# Altium schematic sheet Schematic1.SchDoc
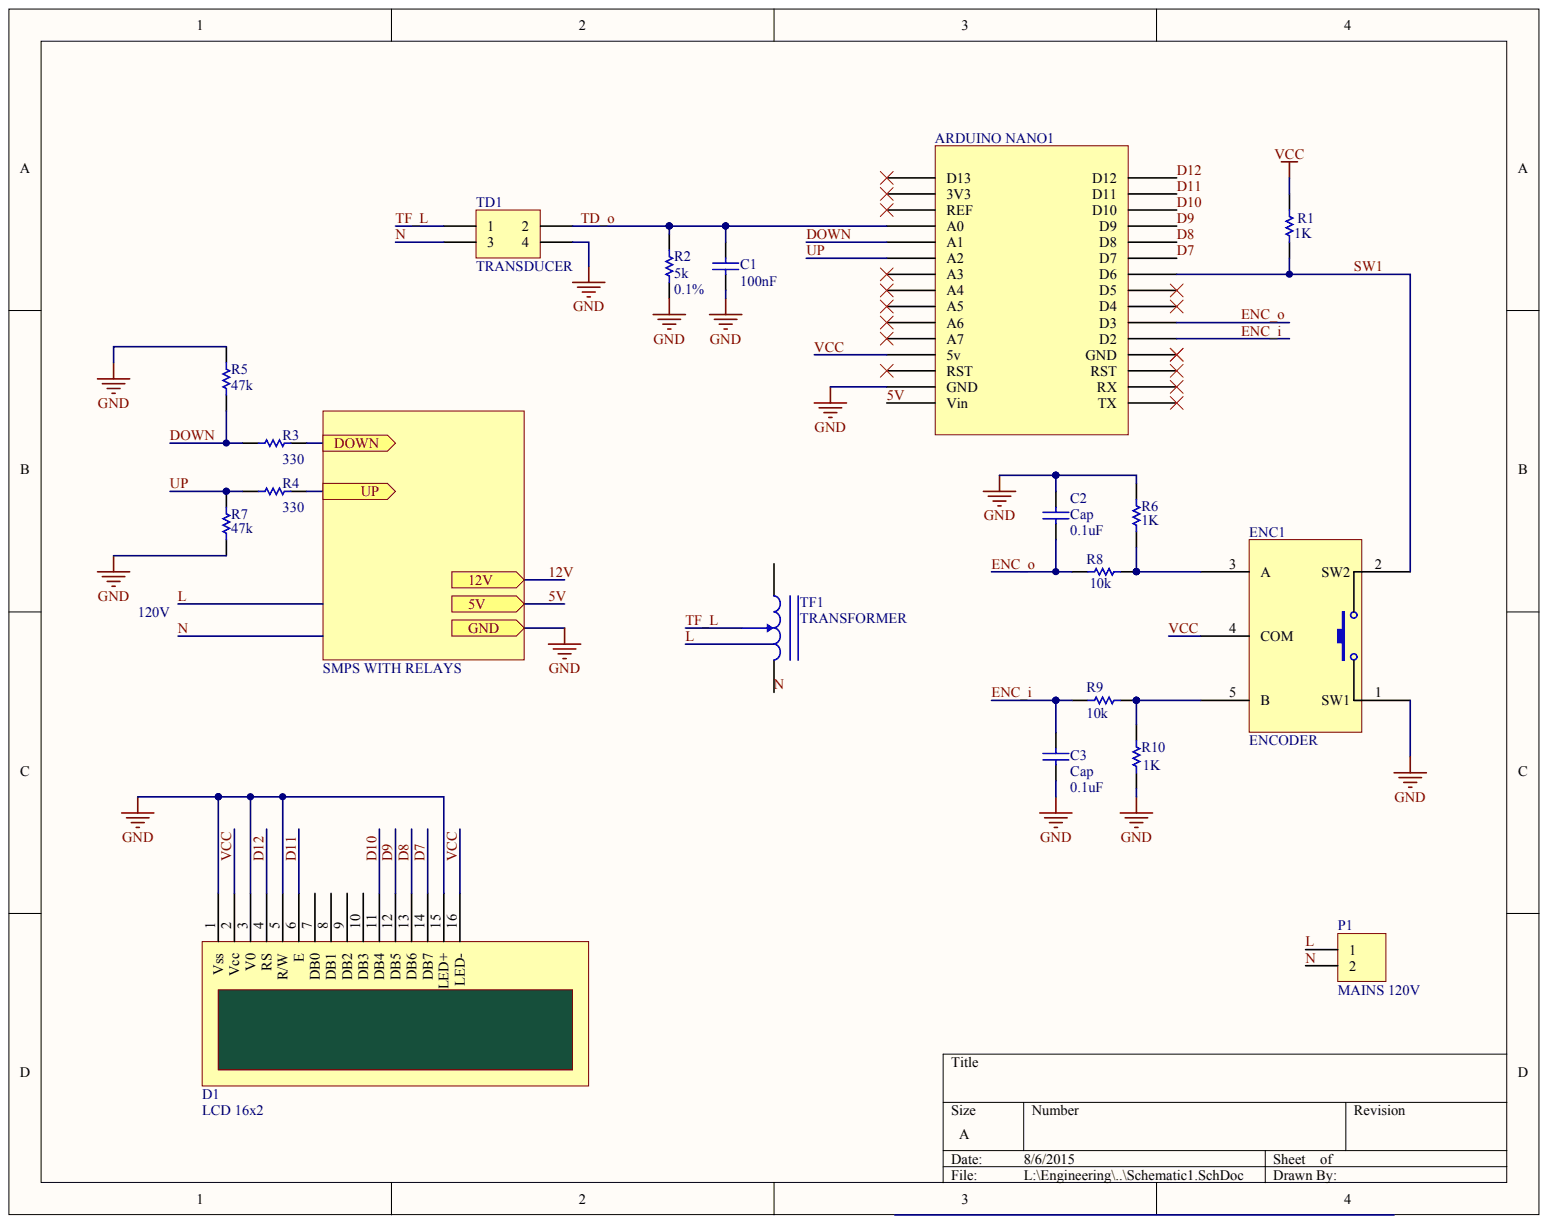
[diagram p0: Schematic1.SchDoc]

## Parts
ARDUINO_NANO1  DUINO NANO
C1  value 100nF  (hidden comment: Cap)
C2  Cap  value 0.1uF
C3  Cap  value 0.1uF
D1  LCD 16x2
ENCODER  ENC1
P1  MAINS 120V
R1  value 1K  (hidden comment: es1)
R2  5k  value 0.1%
R3  value 330  (hidden comment: Res1)
R4  value 330  (hidden comment: Res1)
R5  value 47k  (hidden comment: Res1)
R6  value 1K  (hidden comment: Res1)
R7  value 47k  (hidden comment: Res1)
R8  value 10k  (hidden comment: Res1)
R9  value 10k  (hidden comment: Res1)
R10  value 1K  (hidden comment: Res1)
TD1  TRANSDUCER
TF1  TRANSFORMER

## Ports
5V, 12V, DOWN, GND, UP

## Nets
5V: ARDUINO_NANO1.13 ; port 5V
D7: ARDUINO_NANO1.14 D1.14(DB7)
D8: ARDUINO_NANO1.14 D1.13(DB6)
D9: ARDUINO_NANO1.14 D1.12(DB5)
D10: ARDUINO_NANO1.14 D1.11(DB4)
D11: ARDUINO_NANO1.14 D1.6(E)
D12: ARDUINO_NANO1.14 D1.4(RS)
DOWN: ARDUINO_NANO1.13 R3.2 R5.1
ENC_I: ARDUINO_NANO1.14 C3.2 R9.1
ENC_O: ARDUINO_NANO1.14 C2.1 R8.1
GND: ARDUINO_NANO1.13 C1.2 C2.2 C3.1 D1.1(Vss) D1.3(V0) D1.5(R/W) D1.15(LED+) ENCODER.1(SW1) R2.2 R5.2 R6.2 R7.1 R10.1 TD1.4 ; port GND
L: P1.1
N: P1.2 TD1.3 TF1.2
SW1: ARDUINO_NANO1.14 ENCODER.2(SW2) R1.2
TD_O: ARDUINO_NANO1.13 C1.1 R2.1 TD1.2
TF_L: TD1.1
UP: ARDUINO_NANO1.13 R4.2 R7.2
VCC: ARDUINO_NANO1.13 D1.2(Vcc) D1.16(LED-) ENCODER.4(COM) R1.1
NetENCODER_3: ENCODER.3(A) R6.1 R8.2
NetENCODER_5: ENCODER.5(B) R9.2 R10.2
NetR3_1: R3.1 ; port DOWN
NetR4_1: R4.1 ; port UP
12V: ; port 12V
N00015:

Not connected: D1 7(DB0) 8(DB1) 9(DB2) 10(DB3); TF1 1

## Library data of the parts
TF1 = (no symbol name): Value: 10mH; Set Position: 0.5; Published: 24-Mar-1999; LatestRevisionDate: 17-Jul-2002; LatestRevisionNote: Re-released for DXP Platform.; PackageReference: AXIAL-1.0; PackageDescription: Axial Device, Thru-Hole; 2 Leads; 1.0 in Pin Spacing; Publisher: Altium Limited
ARDUINO_NANO1 C1-C3 D1 ENCODER P1 R1-R10 TD1 = (no symbol name)
  C1-C3: Published: 8-Jun-2000; LatestRevisionDate: 17-Jul-2002; LatestRevisionNote: Re-released for DXP Platform.; PackageReference: RAD-0.3; PackageDescription: Radial Cap, Thru-Hole; 2 Leads; 0.3 in Pin Spacing
  P1 TD1: LatestRevisionDate: 17-Jul-2002; LatestRevisionNote: Re-released for DXP Platform.
  R1-R10: Published: 8-Jun-2000; LatestRevisionDate: 17-Jul-2002; LatestRevisionNote: Re-released for DXP Platform.; PackageReference: AXIAL-0.3; PackageDescription: Axial Device, Thru-Hole; 2 Leads; 0.3 in Pin Spacing
  C1-C3 P1 R1-R10 TD1: Publisher: Altium Limited
